# Altium schematic sheet MCU.SchDoc
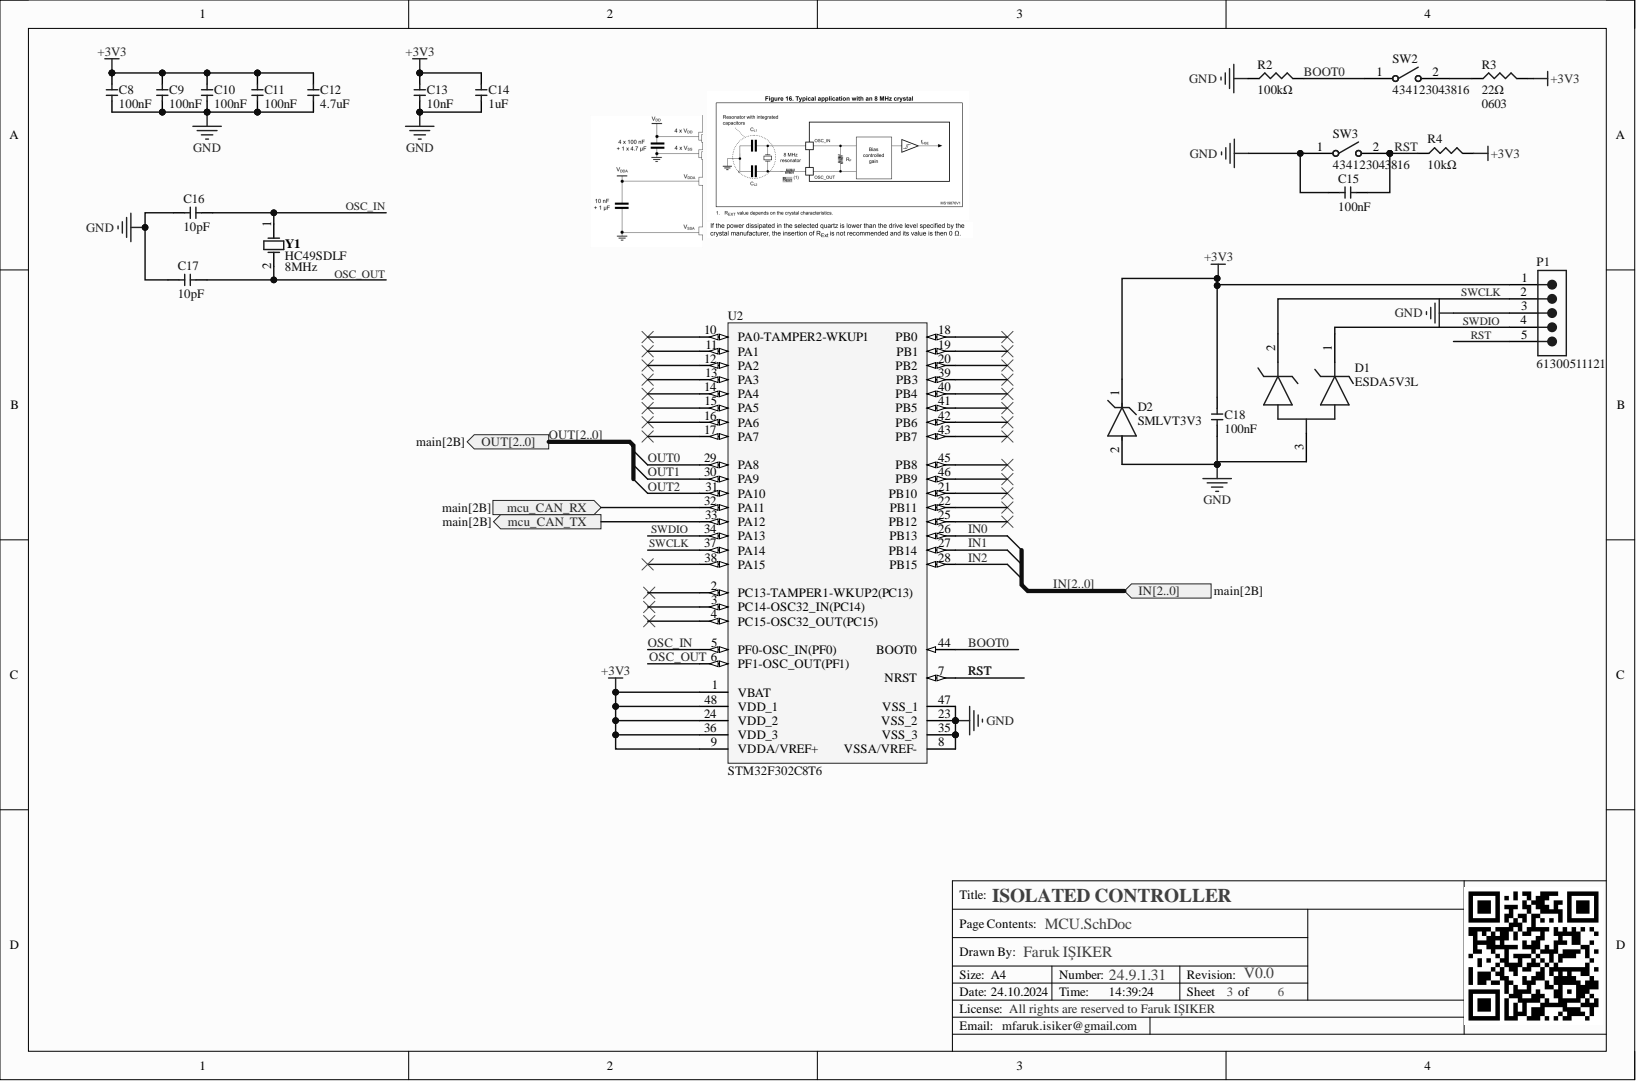
[diagram p0: MCU.SchDoc]

## Parts
C8  value 100nF  [0603_cap]  (hidden comment: 0603_100nF)
C9  value 100nF  [0603_cap]  (hidden comment: 0603_100nF)
C10  value 100nF  [0603_cap]  (hidden comment: 0603_100nF)
C11  value 100nF  [0603_cap]  (hidden comment: 0603_100nF)
C12  value 4.7uF  [0603_cap]  (hidden comment: 0603_4.7uF)
C13  value 10nF  [0603_cap]  (hidden comment: 0603_10nF)
C14  value 1uF  [0603_cap]  (hidden comment: 0603_1uF)
C15  value 100nF  [0603_cap]  (hidden comment: 0603_100nF)
C16  value 10pF  [0603_cap]  (hidden comment: 0603_10pF)
C17  value 10pF  [0603_cap]  (hidden comment: 0603_10pF)
C18  value 100nF  [0603_cap]  (hidden comment: 0603_100nF)
D1  ESDA5V3L  [FP-SOT23-3L-0053390-MFG]
D2  SMLVT3V3  [FP-SMB-MFG]
P1  61300511121  [61300511121]
R2  value 100kΩ  [0603-smd]  (hidden comment: 0603_100K)
R3  0603_22R  value 22Ω  Package: 0603  [0603-smd]
R4  value 10kΩ  [0603-smd]  (hidden comment: 0603_10K)
SW2  434123043816  [WS-TASV_L6W3.5H4.3_4341x30438x6]
SW3  434123043816  [WS-TASV_L6W3.5H4.3_4341x30438x6]
U2  STM32F302C8T6  [STM-LQFP48_L]
Y1  Frequency: 8MHz  Package Reference: HC49SDLF  [FOX-HC49SDLF_V]  (hidden comment: FOXSDLF/080-20)

## Ports
IN0, IN1, IN2, IN[2..0], mcu_CAN_RX, mcu_CAN_TX, OUT0, OUT1, OUT2, OUT[2..0]

## Nets
+3V3: C8.2 C9.2 C10.2 C11.2 C12.2 C13.2 C14.2 C18.2 D2.1 P1.1 R3.2 R4.1 U2.1(VBAT) U2.9(VDDA/VREF+) U2.24(VDD_2) U2.36(VDD_3) U2.48(VDD_1)
GND: C8.1 C9.1 C10.1 C11.1 C12.1 C13.1 C14.1 C15.1 C16.1 C17.1 C18.1 D1.3 D2.2 P1.3 R2.2 SW3.1 U2.8(VSSA/VREF-) U2.23(VSS_2) U2.35(VSS_3) U2.47(VSS_1)
BOOT0: R2.1 SW2.1 U2.44(BOOT0)
IN0: U2.26(PB13) ; port IN[2..0]  [also labelled IN]
IN1: U2.27(PB14) ; port IN[2..0]  [also labelled IN]
IN2: U2.28(PB15) ; port IN[2..0]  [also labelled IN]
mcu_CAN_RX: U2.32(PA11) ; port mcu_CAN_RX
mcu_CAN_TX: U2.33(PA12) ; port mcu_CAN_TX
OSC_IN: C16.2 U2.5(PF0-OSC_IN(PF0)) Y1.1
OSC_OUT: C17.2 U2.6(PF1-OSC_OUT(PF1)) Y1.2
OUT0: U2.29(PA8) ; port OUT[2..0]  [also labelled OUT]
OUT1: U2.30(PA9) ; port OUT[2..0]  [also labelled OUT]
OUT2: U2.31(PA10) ; port OUT[2..0]  [also labelled OUT]
RST: C15.2 P1.5 R4.2 SW3.2 U2.7(NRST)
SWCLK: D1.2 P1.2 U2.37(PA14)
SWDIO: D1.1 P1.4 U2.34(PA13)
NetR3_1: R3.1 SW2.2
NetU2_2: U2.2(PC13-TAMPER1-WKUP2(PC13))
NetU2_3: U2.3(PC14-OSC32_IN(PC14))
NetU2_4: U2.4(PC15-OSC32_OUT(PC15))
NetU2_10: U2.10(PA0-TAMPER2-WKUP1)
NetU2_11: U2.11(PA1)
NetU2_12: U2.12(PA2)
NetU2_13: U2.13(PA3)
NetU2_14: U2.14(PA4)
NetU2_15: U2.15(PA5)
NetU2_16: U2.16(PA6)
NetU2_17: U2.17(PA7)
NetU2_18: U2.18(PB0)
NetU2_19: U2.19(PB1)
NetU2_20: U2.20(PB2)
NetU2_21: U2.21(PB10)
NetU2_22: U2.22(PB11)
NetU2_25: U2.25(PB12)
NetU2_38: U2.38(PA15)
NetU2_39: U2.39(PB3)
NetU2_40: U2.40(PB4)
NetU2_41: U2.41(PB5)
NetU2_42: U2.42(PB6)
NetU2_43: U2.43(PB7)
NetU2_45: U2.45(PB8)
NetU2_46: U2.46(PB9)

## Library data of the parts
C8-C11 C15 C18 = 0603_100nF: Volt: V; Component Kind: Standard; Library Name: generic.SchLib; Package: 0603
C12 = 0603_4.7uF: Volt: 25V; Component Kind: Standard; Library Name: generic.SchLib; Package: 0603
C13 = 0603_10nF: Volt: V; Component Kind: Standard; Library Name: generic.SchLib; Package: 0603
C14 = 0603_1uF: Volt: V; Component Kind: Standard; Library Name: generic.SchLib; Package: 0603
C16 C17 = 0603_10pF: Volt: V; Component Kind: Standard; Library Name: generic.SchLib; Package: 0603
D1 = CMP-12225-000053-1: TVS DIODE 3V SOT23-3; Manufacturer: STMicroelectronics; Breakdown Voltage: 5.3V; Capacitance: 220pF; Direction: Unidirectional; Max Junction Temp: +150°C; Number Of Channels: 2; Peak Pulse Current: 25A; Peak Pulse Power: 300W; Standoff Height: 0mm; Value: 220pF; Automotive: No; Category: Diode; Component Kind: Standard; ComponentLink2Description: Datasheet; ComponentLink2URL: https://www.st.com/content/ccc/resource/technical/document/datasheet/eb/9f/a7/ac/7b/b6/46/7f/CD00002057.pdf/files/CD00002057.pdf/jcr:content/translations/en.CD00002057.pdf; Device Class L1: Circuit Protection; Device Class L2: TVS Diodes; Device Class L3: unset; Digikey Description: TVS DIODE 3V SOT23-3; Height: 1.4mm; Lead Free: Yes; Library Name: Altium Content Vault; Manufacturer Part Number: ESDA5V3L; Mouser Description: ESDA Series 2 Channel 5.3 V 220 pF SMT Uni-Directional Transil? Array - SOT-23; Octopart: https://octopart.com/esda5v3l-stmicroelectronics-347708; Package: SOT23-3L-0053390; Reverse Standoff Voltage: 3V; RoHS: TRUE; Temperature: -40°C to +150°C; Temperature Range High: +150°C; Temperature Range Low: -40°C; Ipc Land Pattern Name: SOT95P255X115-3
D2 = CMP-2000-07127-2: TVS DIODE 3.3V 10.3V SMB; Manufacturer: STMicroelectronics; Breakdown Voltage: 4.1V; Capacitance: 5200pF; Clamping Voltage: 7.3V; Direction: Unidirectional; Max Junction Temp: +175°C; Number Of Channels: 1; Peak Pulse Current: 50A; Peak Pulse Power: 600W; Standoff Height: 0.05mm; Value: 5200pF; Automotive: No; Category: Diode; Component Kind: Standard; ComponentLink2Description: Datasheet; ComponentLink2URL: https://www.st.com/resource/en/datasheet/smlvt3v3.pdf; Device Class L1: Circuit Protection; Device Class L2: TVS Diodes; Device Class L3: unset; Digikey Description: TVS DIODE 3.3V 10.3V SMB; Height: 2.65mm; Lead Free: Yes; Library Name: Altium Content Vault; Manufacturer Part Number: SMLVT3V3; Mouser Description: TVS Diodes - Transient Voltage Suppressors 600W 3.3V Unidirect; Octopart: https://octopart.com/smlvt3v3-stmicroelectronics-347049; Package: SMB; RoHS: TRUE; Temperature: -55°C to +175°C; Temperature Range High: +175°C; Temperature Range Low: -55°C
P1 = CMP-1502-01068-3: NexarPartId: 32855526; Connector Type: Male Pin; Contact Resistance: 20mΩ; Current Rating: 3A; Gender: Male; Number of Contacts: 5; Operating Supply Voltage: 250V; Pitch: 2.54mm; Color: Black; Component Kind: Standard; Contact Material: Copper; Contact Mating Length: 5.9944mm; Contact Plating: Gold; Height: 8.89mm; Lead Free: Lead Free; Lead Pitch: 2.54mm; Library Name: Altium Content Vault; Max Operating Temperature: 105°C; Min Operating Temperature: -40°C; Mount: Through Hole; Number of Pins: 5; Number of Positions: 5; Number of Rows: 1; Orientation: Vertical; Packaging: Bulk; REACH SVHC: Unknown; RoHS: Compliant; Termination: Solder; Voltage Rating: 250V
R2 = 0603_100K: Power: W; Component Kind: Standard; Library Name: generic.SchLib; Package: 0603
R3 = 0603_22R: Power: W; Component Kind: Standard; Library Name: generic.SchLib
R4 = 0603_10K: Power: W; Component Kind: Standard; Library Name: generic.SchLib; Package: 0603
SW2 SW3 = CMP-1460-00005-2: NexarPartId: 22866049; Contact Current Rating: 50mA; Contact Resistance: 100mΩ; Voltage Rating: 12V; Color: Black; Component Kind: Standard; Contact Plating: Silver; Current Rating: 50mA; Height: 4.3mm; Library Name: Altium Content Vault; Max Operating Temperature: 85°C; Min Operating Temperature: -40°C; Packaging: Tape and Reel; RoHS: Compliant; Width: 6mm; Insulation Resistance: 100MΩ
U2 = CMP-0537-00011-1: ARM Cortex-M4 32-bit MCU, 64 KB Flash, 16 KB Internal RAM, 37 I/Os, 48-Pin LQFP, -40 to 85 degC, Tray; D/A Converter: 1; FLASH Size (Prog) (kB): 64; Operating Frequency(F) (Processor speed) (MHz): 72; Supply Voltage(Vcc) min (V): 2; I/Os (High Current): 37; Internal RAM Size (kB): 16; Component Kind: Standard; ComponentLink1Description: Manufacturer URL; ComponentLink1URL: http://www.st.com/; ComponentLink2Description: Datasheet; ComponentLink2URL: http://www.st.com/st-web-ui/static/active/en/resource/technical/document/datasheet/DM00093333.pdf; Core: ARM Cortex-M4; DatasheetVersion: Rev. 5; Library Name: Altium Content Vault; Mounting Technology: Surface Mount; Package Description: 48-Pin Low Profile Quad Flat Package, Body 7 x 7 mm, Pitch 0.5 mm; Package Reference: LQFP48; Packing: Tray
Y1 = CMP-2100-03639-1: Resistance Weld Crystal, 8 MHz, +/- 30 ppm, -20 to 70 degC, 2-Pin SMD, RoHS, Tape and Reel; Manufacturer: FOX Electronics; ESR (Equivalent Series Resistance): 80Ohm; Frequency Stability: 50ppm; Frequency Tolerance: 30ppm; Load Capacitance: 20pF; Case/Package: SMD/SMT; Component Kind: Standard; Datasheet URL: http://www.foxonline.com/pdfs/hc49sdlf.pdf; Datasheet Version: 42064.0; Depth: 5mm; Height: 4.5mm; Lead Free: LeadFree; Length: 11.7mm; Library Name: Altium Content Vault; Manufacturer URL: http://www.foxonline.com/; Max Operating Temperature: 70degC; Min Operating Temperature: -20degC; Mount: SurfaceMount; Number of Pins: 2; Package Description: 2-Pin Surface Mount Device, Body 5 x 11.7 mm; Package Quantity: 1000; Packaging: TapeandReel; Radiation Hardening: No; REACH SVHC: NoSVHC; RoHSCompliant: True; Series Resistance: 80Ohm; Termination: SMD/SMT; Tolerance: 50ppm; Width: 5mm
